# Altium schematic sheet BMS Master.SchDoc
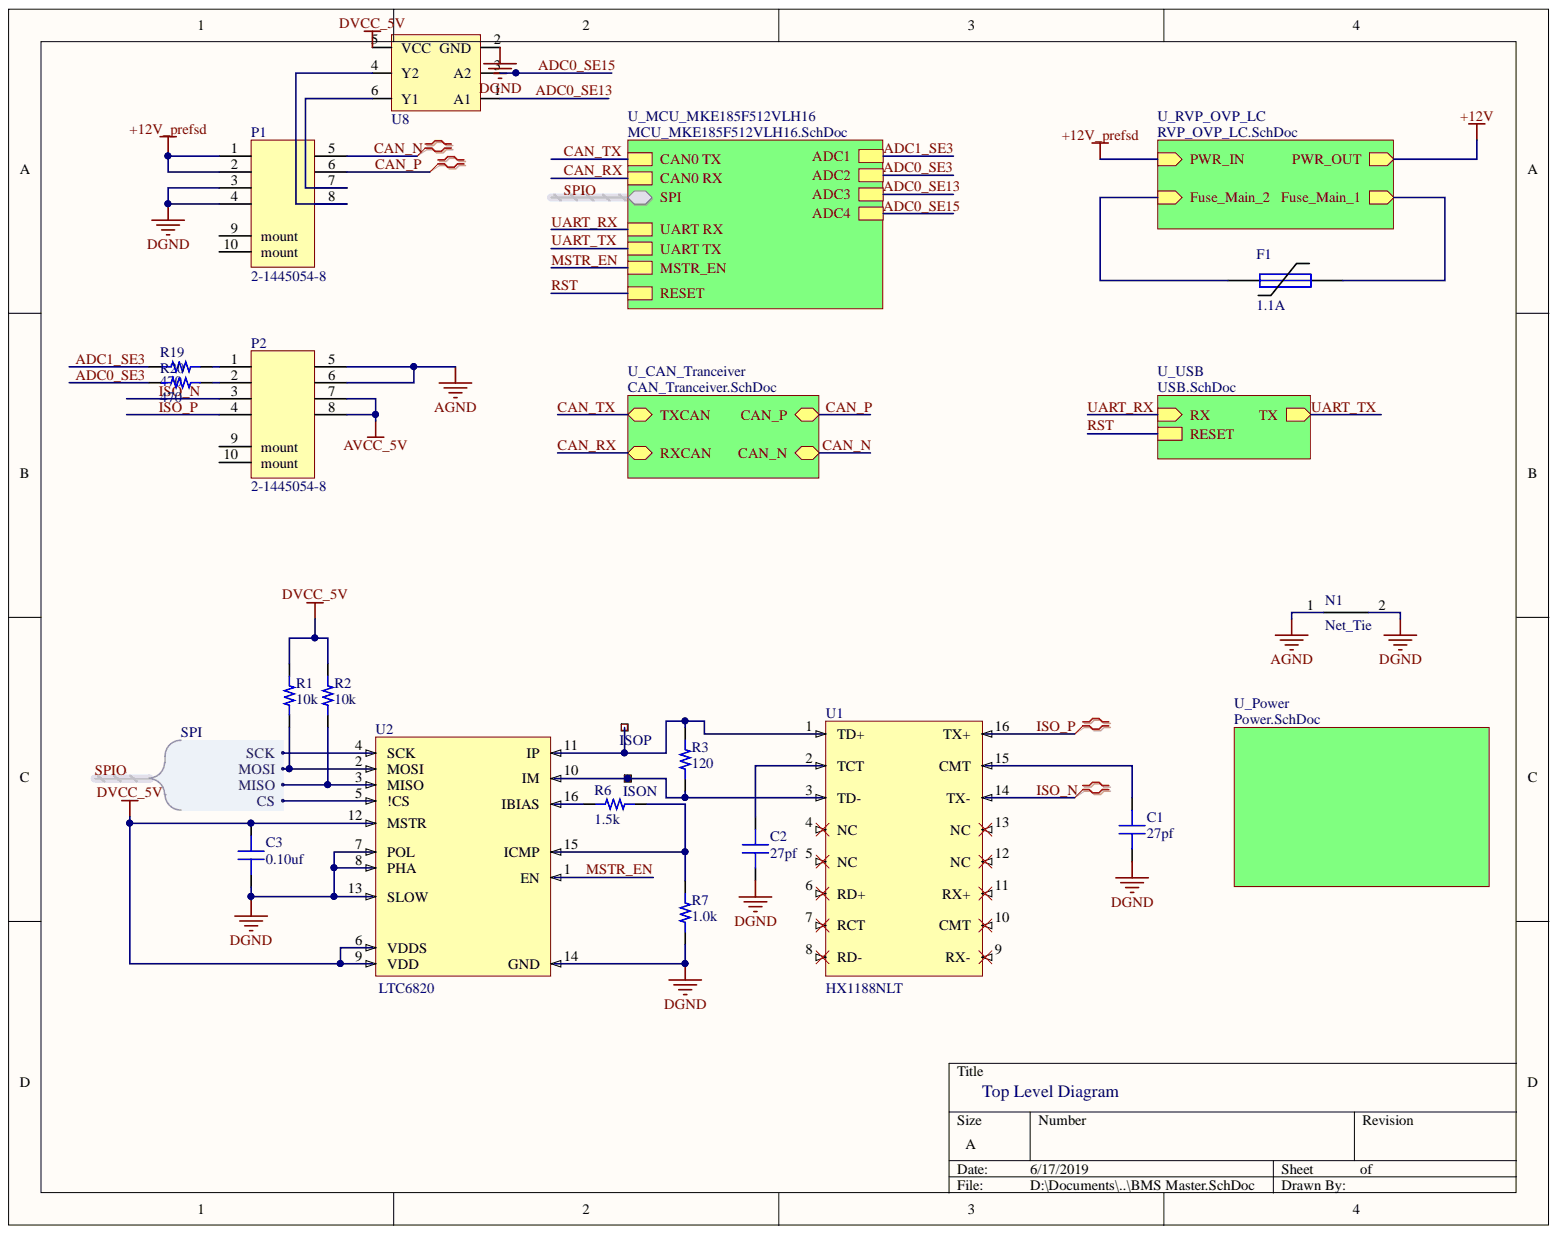
[diagram p0: BMS Master.SchDoc]

## Parts
C1  value 27pf  [CAP 0603/1608]  (hidden comment: Capacitor MLCC 27pf 25V CAP 0603/1608)
C2  value 27pf  [CAP 0603/1608]  (hidden comment: Capacitor MLCC 27pf 25V CAP 0603/1608)
C3  value 0.10uf  [CAP 0603/1608]  (hidden comment: Capacitor MLCC 0.10uf 25V CAP 0603/1608)
F1  I_hold: 1.1A  [PTC Fuse 1206/3216]  (hidden comment: 2.2A)
ISON  RCU-0C  [RCU-0C]  (value not drawn)
ISOP  RCU-0C  [RCU-0C]  (value not drawn)
N1  Net_Tie  [Net_Tie]
P1  2-1445054-8  [2-1445054-8]
P2  2-1445054-8  [2-1445054-8]
R1  value 10k  [RES 0603/1608]  (hidden comment: Resistor 10k 0603 1/10W 5%)
R2  value 10k  [RES 0603/1608]  (hidden comment: Resistor 10k 0603 1/10W 5%)
R3  value 120  [RES 0603/1608]  (hidden comment: Resistor 120 0603 1/10W 5%)
R6  value 1.5k  [RES 0603/1608]  (hidden comment: Resistor 1.5k 0603 1/10W 5%)
R7  value 1.0k  [RES 0603/1608]  (hidden comment: Resistor 1.0k 0603 1/10W 5%)
R19  value 470  [RES 0603/1608]  (hidden comment: Resistor 470 0603 1/10W 5%)
R20  value 470  [RES 0603/1608]  (hidden comment: Resistor 470 0603 1/10W 5%)
U1  HX1188NLT  [HX1188NLT]
U2  LTC6820  [MSOP-16]
U8  comment  [6-TSSOP]  (value not drawn)

## Nets
+12V_prefsd: P1.1 P1.2
AGND: N1.1 P2.5 P2.6
AVCC_5V: P2.7 P2.8
DGND: C1.1 C2.1 C3.2 N1.2 P1.3 P1.4 R7.1 U2.7(POL) U2.8(PHA) U2.13 U2.14 U8.2(GND)
DVCC_5V: C3.1 R1.2 R2.2 U2.6(VDDS) U2.9(VDD) U2.12 U8.5(VCC)
ADC0_SE3: R20.1
ADC0_SE13: U8.1(A1)
ADC0_SE15: U8.3(A2)
ADC1_SE3: R19.1
CAN_N: P1.5
CAN_P: P1.6
ISO_N: P2.3 U1.14(TX-)
ISO_P: P2.4 U1.16
MSTR_EN: U2.1(EN)
SPIO.CS: U2.5(!CS)
SPIO.MISO: R2.1 U2.3(MISO)
SPIO.MOSI: R1.1 U2.2(MOSI)
SPIO.SCK: U2.4(SCK)
NetC1_2: C1.2 U1.15
NetC2_2: C2.2 U1.2(TCT)
NetISON_1: ISON.1 R3.1 U1.3(TD-) U2.10
NetISOP_1: ISOP.1 R3.2 U1.1(TD+) U2.11(IP)
NetP1_7: P1.7 U8.6(Y1)
NetP1_8: P1.8 U8.4(Y2)
NetP2_1: P2.1 R19.2
NetP2_2: P2.2 R20.2
NetR6_1: R6.1 U2.16
NetR6_2: R6.2 R7.2 U2.15(ICMP)
+12V:
CAN_RX:
CAN_TX:
RST:
UART_RX:
UART_TX:

Not connected: F1 1 2; P1 9(mount) 10(mount); P2 9(mount) 10(mount); U1 4(NC) 5(NC) 6(RD+) 7(RCT) 8(RD-) 9(RX-) 10 11 12 13

## Library data of the parts
C1 C2 = 515: Created_By: Nick Mah; Dielectric: Ceramic; Drawer_id: 1; Name: Cap_27pf_25V_CAP 0603/1608; Component Kind: Standard; Created_Date: 10/20/2018; Database Table Name: Capacitor; idCapacitor: 515; Library Name: Altium-Lib.DbLib; Manufacturer_id: 1; Package: CAP 0603/1608; Voltage_Rating: 25V
C3 = 583: Created_By: Nick Mah; Dielectric: Ceramic; Drawer_id: 1; Name: Cap_0.10uf_25V_CAP 0603/1608; Component Kind: Standard; Created_Date: 10/20/2018; Database Table Name: Capacitor; idCapacitor: 583; Library Name: Altium-Lib.DbLib; Manufacturer_id: 1; Package: CAP 0603/1608; Voltage_Rating: 25V
F1 = 8: Fuse; MfrPartNo: 1206L110/12SLYR; Drawer_id: 1; I_max: 50A; Name: Resettable; Pd_Typ: .8W; V_max: 12V; Component Kind: Standard; Database Table Name: Fuse; I_trip: 2.2A; idFuse: 8; LatestRevisionDate: 17-Jul-2002; LatestRevisionNote: Re-released for DXP Platform.; Library Name: Altium-Lib.DbLib; Link: https://www.digikey.com/product-detail/en/littelfuse-inc/1206L110-12SLYR/F5764CT-ND/3593809; Manufacturer_id: 1; PackageDescription: Thru-Hole; 2 Leads; PackageReference: PIN-W2/E2.8; Published: 8-Jun-2000; Simulation: FUSE; Publisher: Altium Limited
ISON ISOP = 14: Test point; Created_By: Graydon; Database Table Name: Connector; Drawer_id: 1; Updated_By: Null; Value: CONN; Component Kind: Standard; ComponentLink1Description: Null; ComponentLink1URL: Null; Created_Date: 11/10/2018; idConn: 14; Library Name: Altium-Lib.DbLib; Link: https://www.digikey.com/product-detail/en/te-connectivity-amp-connectors/RCU-0C/A106145CT-ND/3477802; Manufacturer_id: 1; MfrPartNo: RCU-0C; Name: RCU-0C; Num_Contacts: 1; Num_Rows: 1; Package: RCU-0C; Supplier1: None; Supplier1PartNo: None
N1 = 1: Database Table Name: Shunt; idFuse: 3; Name: Net Tie; Component Kind: Net Tie; Drawer_id: 1; idShunt: 1; Library Name: Altium-Lib.DbLib; Manufacturer_id: 1
P1 P2 = 12: 1x8 connector; Manufacturer_id: 5; Created_By: Graydon; Drawer_id: 1; Name: Micro MATE-N-LOK 1x8; Num_Contacts: 8; Component Kind: Standard; ComponentLink1Description: Datasheet; Created_Date: 11/10/2018; Database Table Name: Connector; idConn: 12; Library Name: Altium-Lib.DbLib; Link: https://www.digikey.com/product-detail/en/te-connectivity-amp-connectors/2-1445054-8/2-1445054-8-ND/1890093; MfrPartNo: 2-1445054-8; Num_Rows: 1; Supplier1: Digi-Key; Supplier1PartNo: 2-1445054-8-ND
R1 R2 = 1393: Created_By: Nick Mah; Drawer_id: 1; Name: Res_10k_0603_1/10W_5%; Component Kind: Standard; ComponentLink1Description: Datasheet; Created_Date: 2018-10-20; Database Table Name: Resistor; idResistor: 1393; Library Name: Altium-Lib.DbLib; Manufacturer_id: 1; Package: 0603; Power: 1/10W; Supplier 1: Digi-Key; Tolerance: 5%
R3 = 1347: Created_By: Nick Mah; Drawer_id: 1; Name: Res_120_0603_1/10W_5%; Component Kind: Standard; ComponentLink1Description: Datasheet; Created_Date: 2018-10-20; Database Table Name: Resistor; idResistor: 1347; Library Name: Altium-Lib.DbLib; Manufacturer_id: 1; Package: 0603; Power: 1/10W; Supplier 1: Digi-Key; Tolerance: 5%
R6 = 1373: Created_By: Nick Mah; Drawer_id: 1; Name: Res_1.5k_0603_1/10W_5%; Component Kind: Standard; ComponentLink1Description: Datasheet; Created_Date: 2018-10-20; Database Table Name: Resistor; idResistor: 1373; Library Name: Altium-Lib.DbLib; Manufacturer_id: 1; Package: 0603; Power: 1/10W; Supplier 1: Digi-Key; Tolerance: 5%
R7 = 1369: Created_By: Nick Mah; Drawer_id: 1; Name: Res_1.0k_0603_1/10W_5%; Component Kind: Standard; ComponentLink1Description: Datasheet; Created_Date: 2018-10-20; Database Table Name: Resistor; idResistor: 1369; Library Name: Altium-Lib.DbLib; Manufacturer_id: 1; Package: 0603; Power: 1/10W; Supplier 1: Digi-Key; Tolerance: 5%
R19 R20 = 1361: Created_By: Nick Mah; Drawer_id: 1; Name: Res_470_0603_1/10W_5%; Component Kind: Standard; ComponentLink1Description: Datasheet; Created_Date: 2018-10-20; Database Table Name: Resistor; idResistor: 1361; Library Name: Altium-Lib.DbLib; Manufacturer_id: 1; Package: 0603; Power: 1/10W; Supplier 1: Digi-Key; Tolerance: 5%
U1 = 8: Created_By: Angel; Database Table Name: IC-Interface; Drawer_id: 1; idFuse: 3; Num_Circuits: 2; Component Kind: Standard; idIC_Interface: 8; Library Name: Altium-Lib.DbLib; Link: https://www.digikey.com/product-detail/en/pulse-electronics-network/HX1188NLT/553-1536-1-ND/3151809?utm_adgroup=Transformers&slid=&gclid=CjwKCAiAhp_jBRAxEiwAXbniXRrdDycXKcdMEppGgTacWMJf80VG2GQDdYxlb9yRzxEZsto0mBbjlhoCtm4QAvD_BwE; Manufacturer_id: 1; MfrPartNo: HX1188NLT; Name: HX1188NLT; Package: HX1188NLT; Type: Magnetic Isolation
U2 = 7: soSPI Isolated Communications Interface; Created_By: Angel; Database Table Name: IC-Interface; Drawer_id: 1; idFuse: 3; Protocol: isoSPI; Vin(Max): 5.5V; Vin(Min): 2.7V; Component Kind: Standard; idIC_Interface: 7; Library Name: Altium-Lib.DbLib; Link: https://www.mouser.com/ProductDetail/Analog-Devices/LTC6820IMSPBF?qs=sGAEpiMZZMvi6wO7nhr1LyTLeBC7dAWQpR44bZH0zYY%3d; Manufacturer_id: 1; MfrPartNo: LTC6820; Name: LTC6820; Num_Circuits: 1; Package: MSOP-16; Type: bidirectional
U8 = 10: Buffer dual high speed; MfrPartNo: NC7WZ07P6X; Created_By: gray; Data_Rate: 2.3ns; Database Table Name: IC-Interface; Drawer_id: 1; Name: Buffer 2x; Num_Circuits: 2; Protocol: None; Updated_By: Null; Value: None; Vin(Max): 5.5V; Vin(Min): 1.65V; Component Kind: Standard; ComponentLink1Description: Digikey; ComponentLink1URL: https://www.digikey.com/product-detail/en/on-semiconductor/NC7WZ07P6X/NC7WZ07P6XCT-ND/965702; Created_Date: 3/6/2019; idIC_Interface: 10; Library Name: Altium-Lib.DbLib; Link: https://www.digikey.com/product-detail/en/on-semiconductor/NC7WZ07P6X/NC7WZ07P6XCT-ND/965702; Manufacturer_id: 1; Package: 6-TSSOP; Supplier1: Digikey; Supplier1PartNo: NC7WZ07P6XCT-ND; Type: Buffer
